# Altium schematic sheet SYS-PS.SchDoc(SYS-PS)
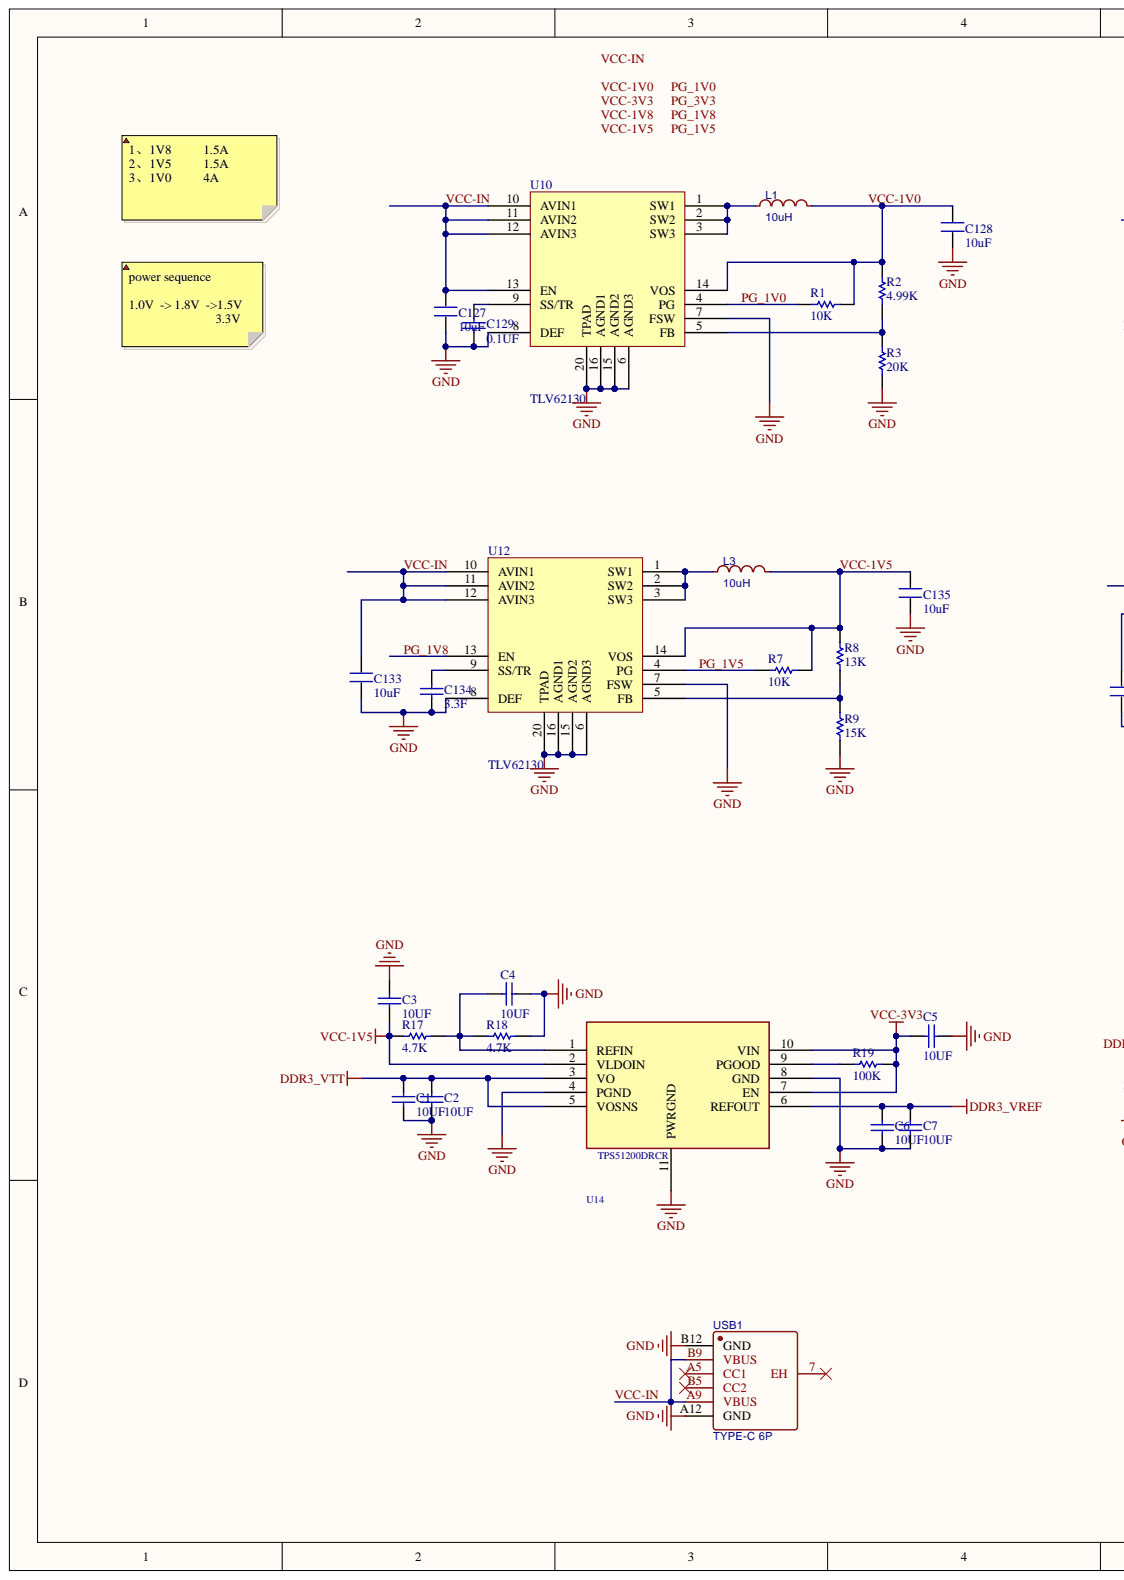
[diagram p0: SYS-PS.SchDoc, left]
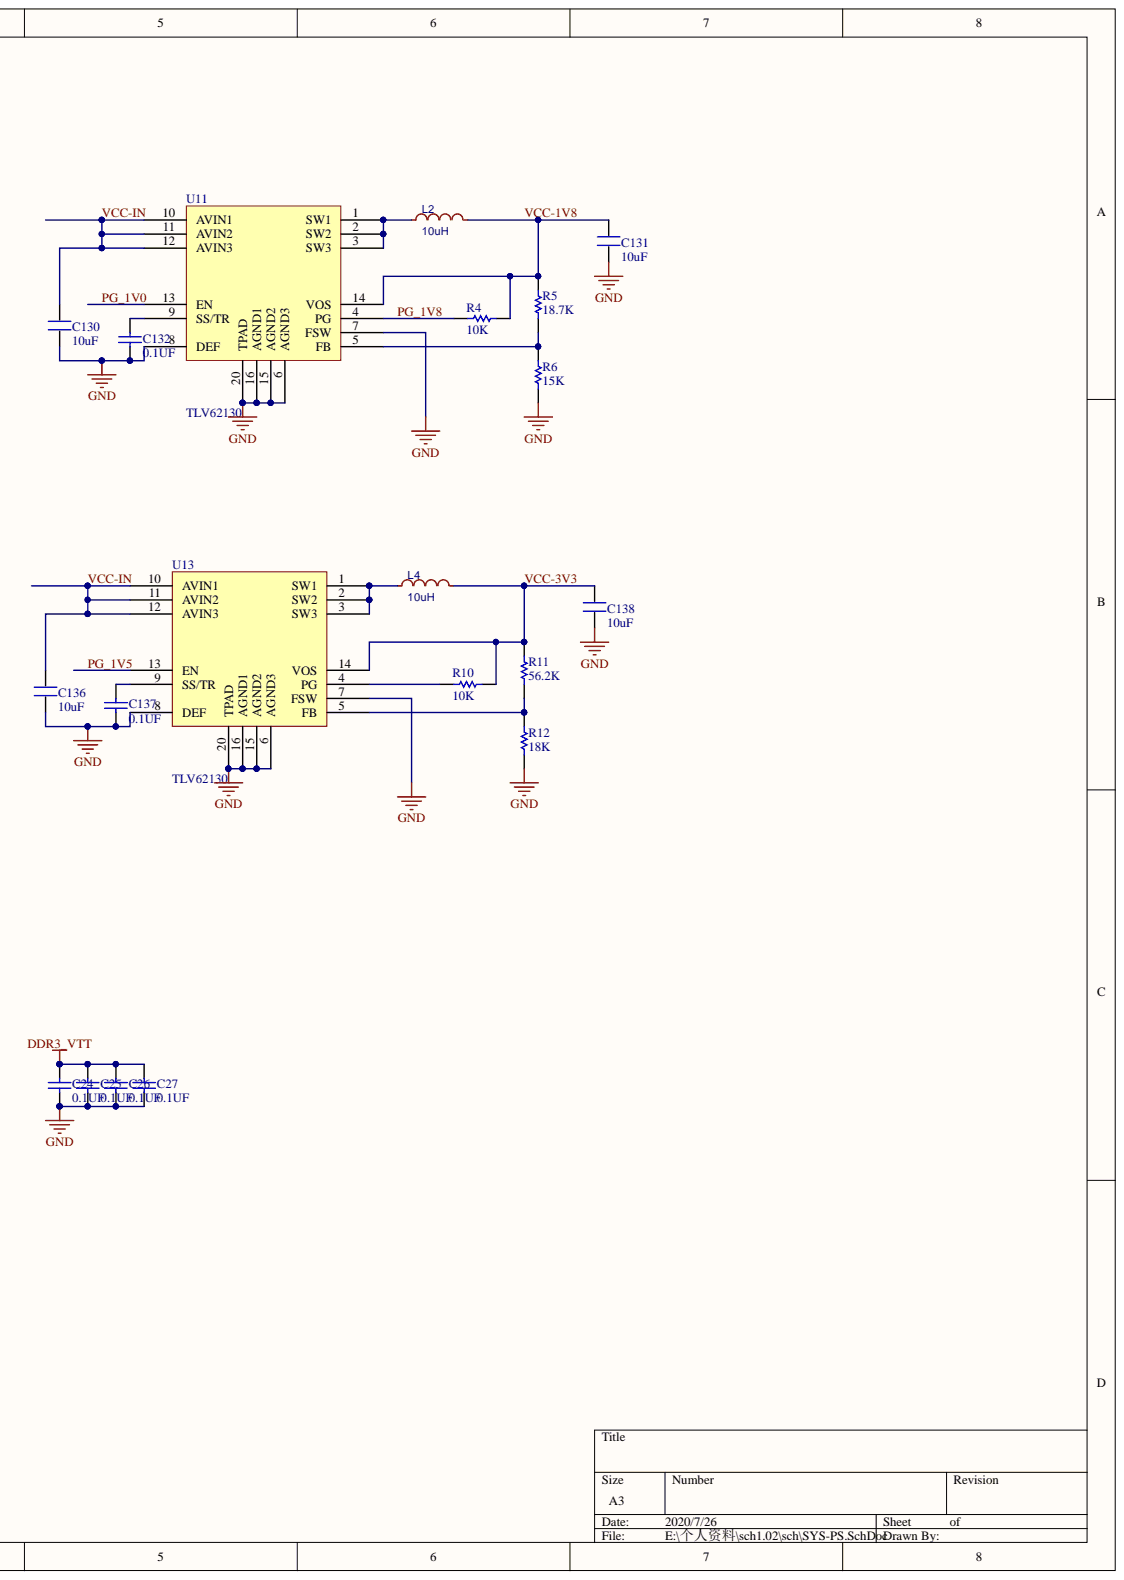
[diagram p1: SYS-PS.SchDoc, right]

## Parts
C1  10UF  [0402]
C2  10UF  [0402]
C3  10UF  [0402]
C4  10UF  [0402]
C5  10UF  [0402]
C6  10UF  [0402]
C7  10UF  [0402]
C24  0.1UF  [0402]
C25  0.1UF  [0402]
C26  0.1UF  [0402]
C27  0.1UF  [0402]
C127  10uF  [C0805]
C128  10uF  [C0805]
C129  0.1UF  [0402]
C130  10uF  [C0805]
C131  10uF  [C0805]
C132  0.1UF  [0402]
C133  10uF  [C0805]
C134  3.3F  [0402]
C135  10uF  [C0805]
C136  10uF  [C0805]
C137  0.1UF  [0402]
C138  10uF  [C0805]
L1  10uH  [L1008]
L2  10uH  [L1008]
L3  10uH  [L1008]
L4  10uH  [L1008]
R1  10K  [0402]
R2  4.99K  [0402]
R3  20K  [0402]
R4  10K  [0402]
R5  value 18.7K  [0402]  (hidden comment: 4.99K)
R6  15K  [0402]
R7  10K  [0402]
R8  value 13K  [0402]  (hidden comment: 4.99K)
R9  15K  [0402]
R10  10K  [0402]
R11  value 56.2K  [0402]  (hidden comment: 4.99K)
R12  value 18K  [0402]  (hidden comment: 15K)
R17  value 4.7K  [0402]  (hidden comment: 1K)
R18  value 4.7K  [0402]  (hidden comment: 1K)
R19  value 100K  [0402]  (hidden comment: 1K)
U10  TLV62130  [TLV62130RGT]
U11  TLV62130  [TLV62130RGT]
U12  TLV62130  [TLV62130RGT]
U13  TLV62130  [TLV62130RGT]
U14  TPS51200DRCR  [TPS_QFN10]
USB1  TYPE-C 6P  [TYPE-C-SMD_TYPE-C-6P]

## Nets
DDR3_VREF: C6.2 C7.2 U14.6(REFOUT)
DDR3_VTT: C1.2 C2.2 C24.2 C25.2 C26.2 C27.2 U14.3(VO) U14.5(VOSNS)
GND: C1.1 C2.1 C3.1 C4.2 C5.2 C6.1 C7.1 C24.1 C25.1 C26.1 C27.1 C127.1 C128.1 C129.1 C130.1 C131.1 C132.1 C133.1 C134.1 C135.1 C136.1 C137.1 C138.1 R3.1 R6.1 R9.1 R12.1 R18.2 U10.6(AGND3) U10.7(FSW) U10.8(DEF) U10.15(AGND2) U10.16(AGND1) U10.20(TPAD) U11.6(AGND3) U11.7(FSW) U11.8(DEF) U11.15(AGND2) U11.16(AGND1) U11.20(TPAD) U12.6(AGND3) U12.7(FSW) U12.8(DEF) U12.15(AGND2) U12.16(AGND1) U12.20(TPAD) U13.6(AGND3) U13.7(FSW) U13.8(DEF) U13.15(AGND2) U13.16(AGND1) U13.20(TPAD) U14.4(PGND) U14.8(GND) U14.11(PWRGND) USB1.A12(GND) USB1.B12(GND)
PG_1V0: R1.1 U10.4(PG) U11.13(EN)
PG_1V5: R7.1 U12.4(PG) U13.13(EN)
PG_1V8: R4.1 U11.4(PG) U12.13(EN)
VCC-1V0: C128.2 L1.2 R1.2 R2.2 U10.14(VOS)
VCC-1V5: C3.2 C135.2 L3.2 R7.2 R8.2 R17.1 U12.14(VOS) U14.2(VLDOIN)
VCC-1V8: C131.2 L2.2 R4.2 R5.2 U11.14(VOS)
VCC-3V3: C5.1 C138.2 L4.2 R10.2 R11.2 R19.2 U13.14(VOS) U14.7(EN) U14.10(VIN)
VCC-IN: C127.2 C130.2 C133.2 C136.2 U10.10(AVIN1) U10.11(AVIN2) U10.12(AVIN3) U10.13(EN) U11.10(AVIN1) U11.11(AVIN2) U11.12(AVIN3) U12.10(AVIN1) U12.11(AVIN2) U12.12(AVIN3) U13.10(AVIN1) U13.11(AVIN2) U13.12(AVIN3) USB1.A9(VBUS) USB1.B9(VBUS)
NetC4_1: C4.1 R17.2 R18.1 U14.1(REFIN)
NetC129_2: C129.2 U10.9(SS/TR)
NetC132_2: C132.2 U11.9(SS/TR)
NetC134_2: C134.2 U12.9(SS/TR)
NetC137_2: C137.2 U13.9(SS/TR)
NetL1_1: L1.1 U10.1(SW1) U10.2(SW2) U10.3(SW3)
NetL2_1: L2.1 U11.1(SW1) U11.2(SW2) U11.3(SW3)
NetL3_1: L3.1 U12.1(SW1) U12.2(SW2) U12.3(SW3)
NetL4_1: L4.1 U13.1(SW1) U13.2(SW2) U13.3(SW3)
NetR2_1: R2.1 R3.2 U10.5(FB)
NetR5_1: R5.1 R6.2 U11.5(FB)
NetR8_1: R8.1 R9.2 U12.5(FB)
NetR10_1: R10.1 U13.4(PG)
NetR11_1: R11.1 R12.2 U13.5(FB)
NetR19_1: R19.1 U14.9(PGOOD)
PG_3V3:

Not connected: USB1 7(EH) A5(CC1) B5(CC2)

## Library data of the parts
C1-C7 C24-C27 = Cap: Capacitor; Simulation: CAP; Signal Integrity: Cap; Published: 8-Jun-2000; PackageReference: RAD-0.3; PackageDescription: Radial Cap, Thru-Hole; 2 Leads; 0.3 in Pin Spacing; Library Name: PCB_Project.IntLib; LatestRevisionNote: Re-released for DXP Platform.; LatestRevisionDate: 17-Jul-2002; Component Kind: Standard; Publisher: Altium Limited
  C1-C7: Value: 10UF
  C24-C27: Value: 0.1UF
C127 C128 C130 C131 C133 C135 C136 C138 = C: Component Kind: Standard; Library Name: ZYNQ领航者底板.IntLib
C129 C132 C134 C137 = Cap: Capacitor; Value: 3.3nF; Simulation: CAP; Signal Integrity: Cap; Published: 8-Jun-2000; PackageReference: RAD-0.3; PackageDescription: Radial Cap, Thru-Hole; 2 Leads; 0.3 in Pin Spacing; Library Name: PCB_Project.IntLib; LatestRevisionNote: Re-released for DXP Platform.; LatestRevisionDate: 17-Jul-2002; Component Kind: Standard; Publisher: Altium Limited
L1-L4 = 10uH: BOM_Manufacturer Part: MPL2520S100MWT; BOM_Manufacturer: Sunlord; nameAlias: Inductance; Contributor: LCSC; spiceSymbolName: MPL2520S100MWT; spicePre: L; Library Name: ZYNQ.SCHLIB; Component Kind: Standard; BOM_Supplier Part: C370039; BOM_Supplier: LCSC
R1-R12 R17-R19 = Res1: Resistor; Simulation: RESISTOR; Signal Integrity: Res; Published: 8-Jun-2000; PackageReference: AXIAL-0.3; PackageDescription: Axial Device, Thru-Hole; 2 Leads; 0.3 in Pin Spacing; Library Name: PCB_Project.IntLib; LatestRevisionNote: Re-released for DXP Platform.; LatestRevisionDate: 17-Jul-2002; Component Kind: Standard; Publisher: Altium Limited
  R1 R4 R7 R10: Value: 10K
  R2: Value: 4.99K
  R3: Value: 20K
  R6 R9: Value: 15K
USB1 = TYPE-C 6P: BOM_Manufacturer: SHOU HAN; Contributor: LCSC; spiceSymbolName: TYPE-C 6P; spicePre: U; Library Name: ZYNQ.SCHLIB; Component Kind: Standard; BOM_Supplier Part: C456012; BOM_Supplier: LCSC; BOM_Manufacturer Part: TYPE-C 6P
U10-U13 = TLV62130: Library Name: ZYNQ.SCHLIB; Component Kind: Standard
U14 = TPS51200DRCR: Library Name: ZYNQ_7020.SCHLIB; Component Kind: Standard
